# Altium schematic sheet [08] - ISOLATE SIGNAL.SchDoc("[08] - ISOLATE SIGNAL")
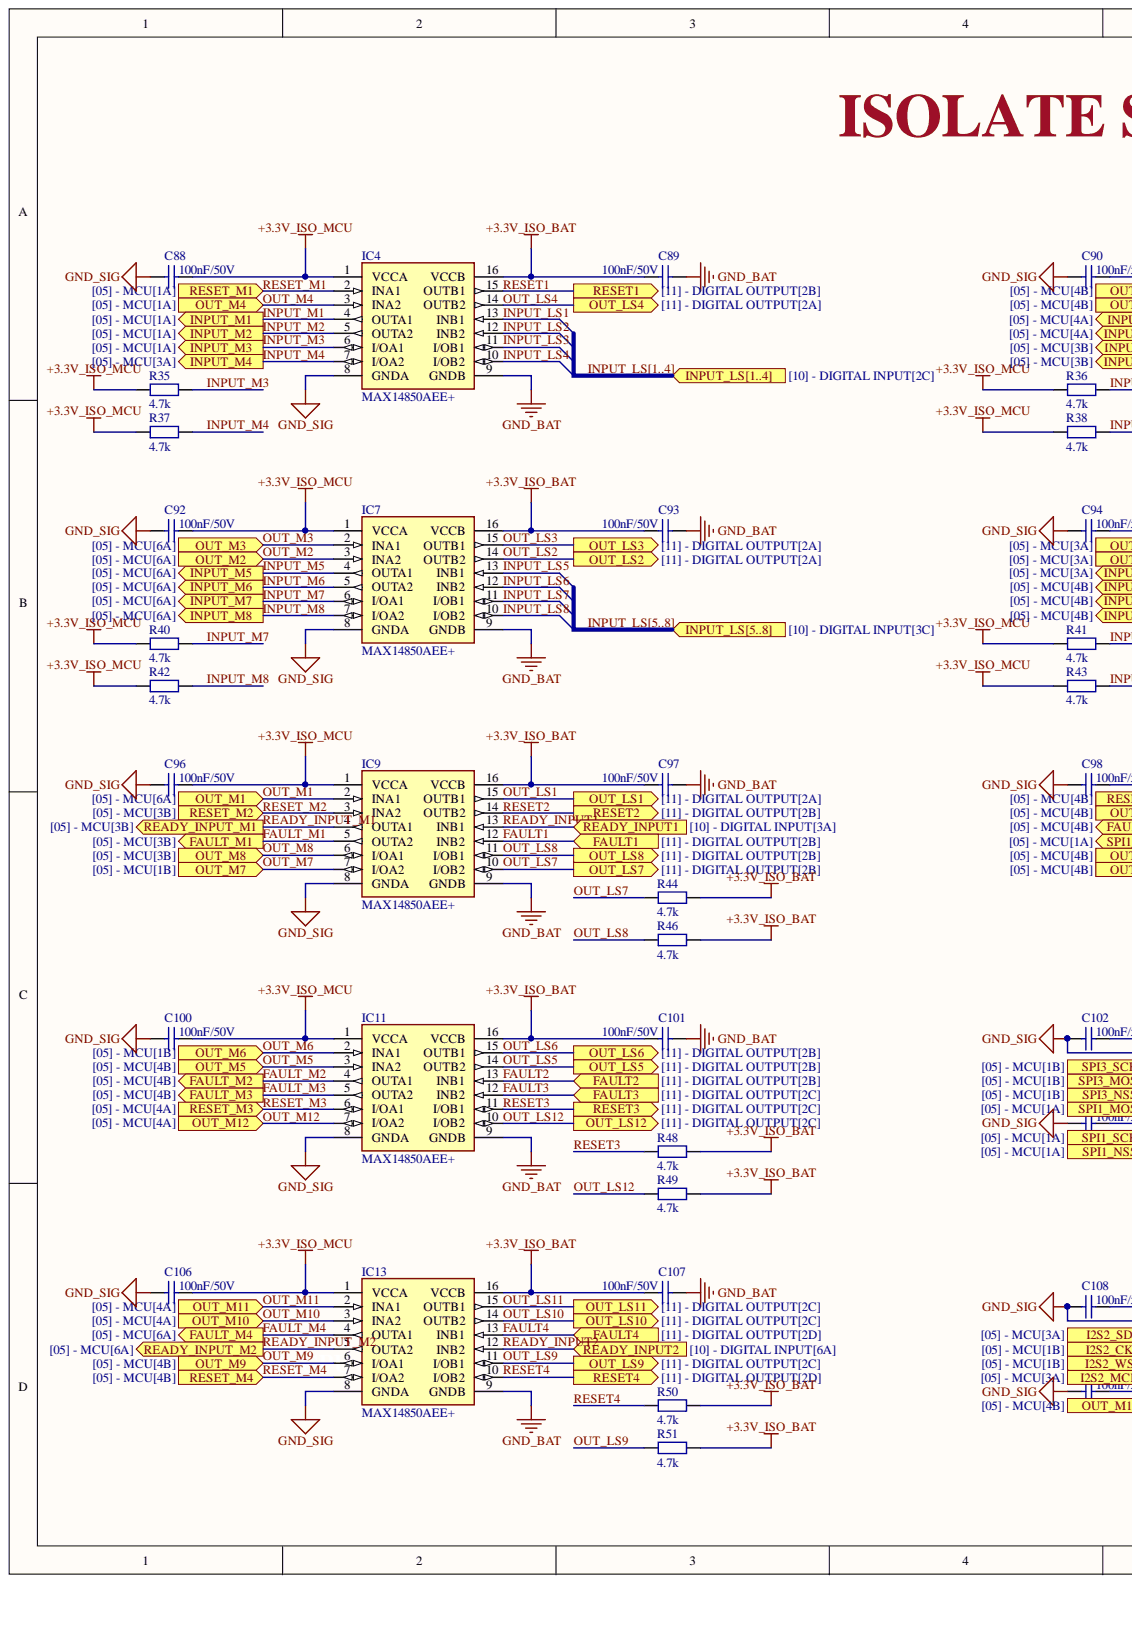
[diagram p0: [08] - ISOLATE SIGNAL.SchDoc, left]
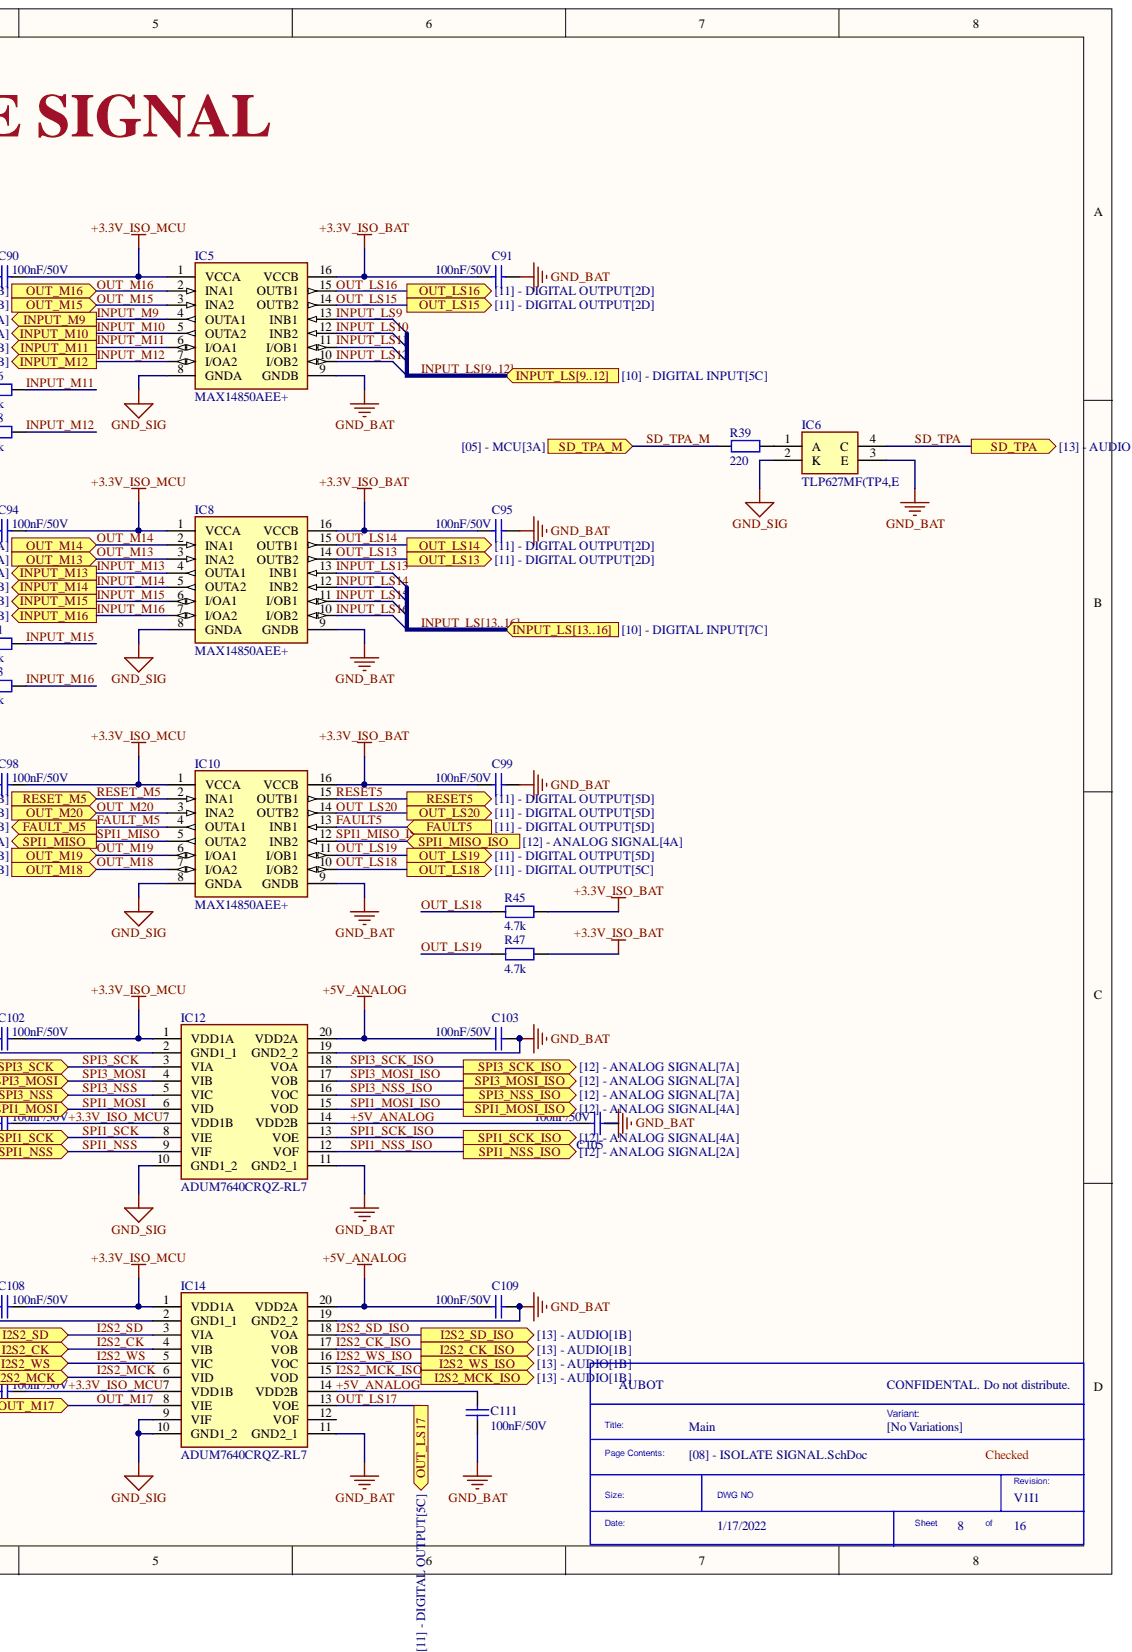
[diagram p1: [08] - ISOLATE SIGNAL.SchDoc, right]

## Parts
C88  100nF/50V  [CAP 0805]
C89  100nF/50V  [CAP 0805]
C90  100nF/50V  [CAP 0805]
C91  100nF/50V  [CAP 0805]
C92  100nF/50V  [CAP 0805]
C93  100nF/50V  [CAP 0805]
C94  100nF/50V  [CAP 0805]
C95  100nF/50V  [CAP 0805]
C96  100nF/50V  [CAP 0805]
C97  100nF/50V  [CAP 0805]
C98  100nF/50V  [CAP 0805]
C99  100nF/50V  [CAP 0805]
C100  100nF/50V  [CAP 0805]
C101  100nF/50V  [CAP 0805]
C102  100nF/50V  [CAP 0805]
C103  100nF/50V  [CAP 0805]
C104  100nF/50V  [CAP 0805]
C105  100nF/50V  [CAP 0805]
C106  100nF/50V  [CAP 0805]
C107  100nF/50V  [CAP 0805]
C108  100nF/50V  [CAP 0805]
C109  100nF/50V  [CAP 0805]
C110  100nF/50V  [CAP 0805]
C111  100nF/50V  [CAP 0805]
IC4  MAX14850AEE+  [QSOP16]
IC5  MAX14850AEE+  [QSOP16]
IC6  TLP627MF(TP4,E  [SOP4-OPTO]
IC7  MAX14850AEE+  [QSOP16]
IC8  MAX14850AEE+  [QSOP16]
IC9  MAX14850AEE+  [QSOP16]
IC10  MAX14850AEE+  [QSOP16]
IC11  MAX14850AEE+  [QSOP16]
IC12  ADUM7640CRQZ-RL7  [20-Lead QSOP]
IC13  MAX14850AEE+  [QSOP16]
IC14  ADUM7640CRQZ-RL7  [20-Lead QSOP]
R35  4.7k  [RES 0805]
R36  4.7k  [RES 0805]
R37  4.7k  [RES 0805]
R38  4.7k  [RES 0805]
R39  220  [RES 0805]
R40  4.7k  [RES 0805]
R41  4.7k  [RES 0805]
R42  4.7k  [RES 0805]
R43  4.7k  [RES 0805]
R44  4.7k  [RES 0805]
R45  4.7k  [RES 0805]
R46  4.7k  [RES 0805]
R47  4.7k  [RES 0805]
R48  4.7k  [RES 0805]
R49  4.7k  [RES 0805]
R50  4.7k  [RES 0805]
R51  4.7k  [RES 0805]

## Ports
FAULT1, FAULT2, FAULT3, FAULT4, FAULT5, FAULT_M1, FAULT_M2, FAULT_M3, FAULT_M4, FAULT_M5, I2S2_CK, I2S2_CK_ISO, I2S2_MCK, I2S2_MCK_ISO, I2S2_SD, I2S2_SD_ISO, I2S2_WS, I2S2_WS_ISO, INPUT_LS1, INPUT_LS2, INPUT_LS3, INPUT_LS4, INPUT_LS5, INPUT_LS6, INPUT_LS7, INPUT_LS8, INPUT_LS9, INPUT_LS10, INPUT_LS11, INPUT_LS12, INPUT_LS13, INPUT_LS14, INPUT_LS15, INPUT_LS16, INPUT_LS[1..4], INPUT_LS[5..8], INPUT_LS[9..12], INPUT_LS[13..16], INPUT_M1, INPUT_M2, INPUT_M3, INPUT_M4, INPUT_M5, INPUT_M6, INPUT_M7, INPUT_M8, INPUT_M9, INPUT_M10, INPUT_M11, INPUT_M12, INPUT_M13, INPUT_M14, INPUT_M15, INPUT_M16, OUT_LS1, OUT_LS2, OUT_LS3, OUT_LS4, OUT_LS5, OUT_LS6, OUT_LS7, OUT_LS8, OUT_LS9, OUT_LS10, OUT_LS11, OUT_LS12, OUT_LS13, OUT_LS14, OUT_LS15, OUT_LS16, OUT_LS17, OUT_LS18, OUT_LS19, OUT_LS20, OUT_M1, OUT_M2, OUT_M3, OUT_M4, OUT_M5, OUT_M6, OUT_M7, OUT_M8, OUT_M9, OUT_M10, OUT_M11, OUT_M12, OUT_M13, OUT_M14, OUT_M15, OUT_M16, OUT_M17, OUT_M18, OUT_M19, OUT_M20, READY_INPUT1, READY_INPUT2, READY_INPUT_M1, READY_INPUT_M2, RESET1, RESET2, RESET3, RESET4, RESET5, RESET_M1, RESET_M2, RESET_M3, RESET_M4, RESET_M5, SD_TPA, SD_TPA_M, SPI1_MISO, SPI1_MISO_ISO, SPI1_MOSI, SPI1_MOSI_ISO, SPI1_NSS, SPI1_NSS_ISO, SPI1_SCK, SPI1_SCK_ISO, SPI3_MOSI, SPI3_MOSI_ISO, SPI3_NSS, SPI3_NSS_ISO, SPI3_SCK, SPI3_SCK_ISO

## Nets
+3.3V_ISO_BAT: C89.1 C91.1 C93.1 C95.1 C97.1 C99.1 C101.1 C107.1 IC4.16(VCCB) IC5.16(VCCB) IC7.16(VCCB) IC8.16(VCCB) IC9.16(VCCB) IC10.16(VCCB) IC11.16(VCCB) IC13.16(VCCB) R44.2 R45.2 R46.2 R47.2 R48.2 R49.2 R50.2 R51.2
GND_BAT: C89.2 C91.2 C93.2 C95.2 C97.2 C99.2 C101.2 C103.2 C105.2 C107.2 C109.2 C111.1 IC4.9(GNDB) IC5.9(GNDB) IC6.3(E) IC7.9(GNDB) IC8.9(GNDB) IC9.9(GNDB) IC10.9(GNDB) IC11.9(GNDB) IC12.11(GND2_1) IC12.19(GND2_2) IC13.9(GNDB) IC14.11(GND2_1) IC14.19(GND2_2)
GND_SIG: C88.1 C90.1 C92.1 C94.1 C96.1 C98.1 C100.1 C102.1 C104.1 C106.1 C108.1 C110.1 IC4.8(GNDA) IC5.8(GNDA) IC6.2(K) IC7.8(GNDA) IC8.8(GNDA) IC9.8(GNDA) IC10.8(GNDA) IC11.8(GNDA) IC12.2(GND1_1) IC12.10(GND1_2) IC13.8(GNDA) IC14.2(GND1_1) IC14.9(VIF) IC14.10(GND1_2)
+3.3V_ISO_MCU: C88.2 C90.2 C92.2 C94.2 C96.2 C98.2 C100.2 C102.2 C104.2 C106.2 C108.2 C110.2 IC4.1(VCCA) IC5.1(VCCA) IC7.1(VCCA) IC8.1(VCCA) IC9.1(VCCA) IC10.1(VCCA) IC11.1(VCCA) IC12.1(VDD1A) IC12.7(VDD1B) IC13.1(VCCA) IC14.1(VDD1A) IC14.7(VDD1B) R35.1 R36.1 R37.1 R38.1 R40.1 R41.1 R42.1 R43.1
+5V_ANALOG: C103.1 C105.1 C109.1 C111.2 IC12.14(VDD2B) IC12.20(VDD2A) IC14.14(VDD2B) IC14.20(VDD2A)
FAULT1: IC9.12(INB2) ; port FAULT1
FAULT2: IC11.13(INB1) ; port FAULT2
FAULT3: IC11.12(INB2) ; port FAULT3
FAULT4: IC13.13(INB1) ; port FAULT4
FAULT5: IC10.13(INB1) ; port FAULT5
FAULT_M1: IC9.5(OUTA2) ; port FAULT_M1
FAULT_M2: IC11.4(OUTA1) ; port FAULT_M2
FAULT_M3: IC11.5(OUTA2) ; port FAULT_M3
FAULT_M4: IC13.4(OUTA1) ; port FAULT_M4
FAULT_M5: IC10.4(OUTA1) ; port FAULT_M5
I2S2_CK: IC14.4(VIB) ; port I2S2_CK
I2S2_CK_ISO: IC14.17(VOB) ; port I2S2_CK_ISO
I2S2_MCK: IC14.6(VID) ; port I2S2_MCK
I2S2_MCK_ISO: IC14.15(VOD) ; port I2S2_MCK_ISO
I2S2_SD: IC14.3(VIA) ; port I2S2_SD
I2S2_SD_ISO: IC14.18(VOA) ; port I2S2_SD_ISO
I2S2_WS: IC14.5(VIC) ; port I2S2_WS
I2S2_WS_ISO: IC14.16(VOC) ; port I2S2_WS_ISO
INPUT_LS1: IC4.13(INB1) ; port INPUT_LS[1..4]  [also labelled INPUT_LS]
INPUT_LS2: IC4.12(INB2) ; port INPUT_LS[1..4]  [also labelled INPUT_LS]
INPUT_LS3: IC4.11(I/OB1) ; port INPUT_LS[1..4]  [also labelled INPUT_LS]
INPUT_LS4: IC4.10(I/OB2) ; port INPUT_LS[1..4]  [also labelled INPUT_LS]
INPUT_LS5: IC7.13(INB1) ; port INPUT_LS[5..8]  [also labelled INPUT_LS]
INPUT_LS6: IC7.12(INB2) ; port INPUT_LS[5..8]  [also labelled INPUT_LS]
INPUT_LS7: IC7.11(I/OB1) ; port INPUT_LS[5..8]  [also labelled INPUT_LS]
INPUT_LS8: IC7.10(I/OB2) ; port INPUT_LS[5..8]  [also labelled INPUT_LS]
INPUT_LS9: IC5.13(INB1) ; port INPUT_LS[9..12]  [also labelled INPUT_LS]
INPUT_LS10: IC5.12(INB2) ; port INPUT_LS[9..12]  [also labelled INPUT_LS]
INPUT_LS11: IC5.11(I/OB1) ; port INPUT_LS[9..12]  [also labelled INPUT_LS]
INPUT_LS12: IC5.10(I/OB2) ; port INPUT_LS[9..12]  [also labelled INPUT_LS]
INPUT_LS13: IC8.13(INB1) ; port INPUT_LS[13..16]  [also labelled INPUT_LS]
INPUT_LS14: IC8.12(INB2) ; port INPUT_LS[13..16]  [also labelled INPUT_LS]
INPUT_LS15: IC8.11(I/OB1) ; port INPUT_LS[13..16]  [also labelled INPUT_LS]
INPUT_LS16: IC8.10(I/OB2) ; port INPUT_LS[13..16]  [also labelled INPUT_LS]
INPUT_M1: IC4.4(OUTA1) ; port INPUT_M1
INPUT_M2: IC4.5(OUTA2) ; port INPUT_M2
INPUT_M3: IC4.6(I/OA1) R35.2 ; port INPUT_M3
INPUT_M4: IC4.7(I/OA2) R37.2 ; port INPUT_M4
INPUT_M5: IC7.4(OUTA1) ; port INPUT_M5
INPUT_M6: IC7.5(OUTA2) ; port INPUT_M6
INPUT_M7: IC7.6(I/OA1) R40.2 ; port INPUT_M7
INPUT_M8: IC7.7(I/OA2) R42.2 ; port INPUT_M8
INPUT_M9: IC5.4(OUTA1) ; port INPUT_M9
INPUT_M10: IC5.5(OUTA2) ; port INPUT_M10
INPUT_M11: IC5.6(I/OA1) R36.2 ; port INPUT_M11
INPUT_M12: IC5.7(I/OA2) R38.2 ; port INPUT_M12
INPUT_M13: IC8.4(OUTA1) ; port INPUT_M13
INPUT_M14: IC8.5(OUTA2) ; port INPUT_M14
INPUT_M15: IC8.6(I/OA1) R41.2 ; port INPUT_M15
INPUT_M16: IC8.7(I/OA2) R43.2 ; port INPUT_M16
OUT_LS1: IC9.15(OUTB1) ; port OUT_LS1
OUT_LS2: IC7.14(OUTB2) ; port OUT_LS2
OUT_LS3: IC7.15(OUTB1) ; port OUT_LS3
OUT_LS4: IC4.14(OUTB2) ; port OUT_LS4
OUT_LS5: IC11.14(OUTB2) ; port OUT_LS5
OUT_LS6: IC11.15(OUTB1) ; port OUT_LS6
OUT_LS7: IC9.10(I/OB2) R44.1 ; port OUT_LS7
OUT_LS8: IC9.11(I/OB1) R46.1 ; port OUT_LS8
OUT_LS9: IC13.11(I/OB1) R51.1 ; port OUT_LS9
OUT_LS10: IC13.14(OUTB2) ; port OUT_LS10
OUT_LS11: IC13.15(OUTB1) ; port OUT_LS11
OUT_LS12: IC11.10(I/OB2) R49.1 ; port OUT_LS12
OUT_LS13: IC8.14(OUTB2) ; port OUT_LS13
OUT_LS14: IC8.15(OUTB1) ; port OUT_LS14
OUT_LS15: IC5.14(OUTB2) ; port OUT_LS15
OUT_LS16: IC5.15(OUTB1) ; port OUT_LS16
OUT_LS17: IC14.13(VOE) ; port OUT_LS17
OUT_LS18: IC10.10(I/OB2) R45.1 ; port OUT_LS18
OUT_LS19: IC10.11(I/OB1) R47.1 ; port OUT_LS19
OUT_LS20: IC10.14(OUTB2) ; port OUT_LS20
OUT_M1: IC9.2(INA1) ; port OUT_M1
OUT_M2: IC7.3(INA2) ; port OUT_M2
OUT_M3: IC7.2(INA1) ; port OUT_M3
OUT_M4: IC4.3(INA2) ; port OUT_M4
OUT_M5: IC11.3(INA2) ; port OUT_M5
OUT_M6: IC11.2(INA1) ; port OUT_M6
OUT_M7: IC9.7(I/OA2) ; port OUT_M7
OUT_M8: IC9.6(I/OA1) ; port OUT_M8
OUT_M9: IC13.6(&) ; port OUT_M9
OUT_M10: IC13.3(INA2) ; port OUT_M10
OUT_M11: IC13.2(INA1) ; port OUT_M11
OUT_M12: IC11.7(I/OA2) ; port OUT_M12
OUT_M13: IC8.3(INA2) ; port OUT_M13
OUT_M14: IC8.2(INA1) ; port OUT_M14
OUT_M15: IC5.3(INA2) ; port OUT_M15
OUT_M16: IC5.2(INA1) ; port OUT_M16
OUT_M17: IC14.8(VIE) ; port OUT_M17
OUT_M18: IC10.7(I/OA2) ; port OUT_M18
OUT_M19: IC10.6(I/OA1) ; port OUT_M19
OUT_M20: IC10.3(INA2) ; port OUT_M20
READY_INPUT1: IC9.13(INB1) ; port READY_INPUT1
READY_INPUT2: IC13.12(INB2) ; port READY_INPUT2
READY_INPUT_M1: IC9.4(OUTA1) ; port READY_INPUT_M1
READY_INPUT_M2: IC13.5(OUTA2) ; port READY_INPUT_M2
RESET1: IC4.15(OUTB1) ; port RESET1
RESET2: IC9.14(OUTB2) ; port RESET2
RESET3: IC11.11(I/OB1) R48.1 ; port RESET3
RESET4: IC13.10(I/OB2) R50.1 ; port RESET4
RESET5: IC10.15(OUTB1) ; port RESET5
RESET_M1: IC4.2(INA1) ; port RESET_M1
RESET_M2: IC9.3(INA2) ; port RESET_M2
RESET_M3: IC11.6(I/OA1) ; port RESET_M3
RESET_M4: IC13.7(I/OA2) ; port RESET_M4
RESET_M5: IC10.2(INA1) ; port RESET_M5
SD_TPA: IC6.4(C) ; port SD_TPA
SD_TPA_M: R39.2 ; port SD_TPA_M
SPI1_MISO: IC10.5(OUTA2) ; port SPI1_MISO
SPI1_MISO_ISO: IC10.12(INB2) ; port SPI1_MISO_ISO
SPI1_MOSI: IC12.6(VID) ; port SPI1_MOSI
SPI1_MOSI_ISO: IC12.15(VOD) ; port SPI1_MOSI_ISO
SPI1_NSS: IC12.9(VIF) ; port SPI1_NSS
SPI1_NSS_ISO: IC12.12(VOF) ; port SPI1_NSS_ISO
SPI1_SCK: IC12.8(VIE) ; port SPI1_SCK
SPI1_SCK_ISO: IC12.13(VOE) ; port SPI1_SCK_ISO
SPI3_MOSI: IC12.4(VIB) ; port SPI3_MOSI
SPI3_MOSI_ISO: IC12.17(VOB) ; port SPI3_MOSI_ISO
SPI3_NSS: IC12.5(VIC) ; port SPI3_NSS
SPI3_NSS_ISO: IC12.16(VOC) ; port SPI3_NSS_ISO
SPI3_SCK: IC12.3(VIA) ; port SPI3_SCK
SPI3_SCK_ISO: IC12.18(VOA) ; port SPI3_SCK_ISO
NetIC6_1: IC6.1(A) R39.1

Not connected: IC14 12(VOF)

## Library data of the parts
C88-C111 = Cap 0805 100nF/50V: Ceramic capacitor SMD-0805 100nF /50V X7R# K +-10%, Samsung, RoHS; Manufacturer Part Number: CL21B104KBCNNNC; Voltage Rating (DC): 50V; Tolerance: 10%; Dielectric: X7R; Width: 0.049inch; Voltage Rating: 50V; Voltage: 50V; Thickness: 0.037inch; Supplier Part Number 1: C1711; Supplier 1: LCSC; Stock 1: 447650; Series: CL; RoHS Compliant: Yes; RoHS: Yes; Radiation Hardening: No; Pricing 1: 50 = 0.04090, 500 = 0.03130, 1500 = 0.02890, 5000 = 0.02580, 25000 = 0.02430, 50000 = 0.02410, 50 = 0.03950, 500 = 0.03020, 1500 = 0.02790, 5000 = 0.02490, 25000 = 0.02350, 50000 = 0.02330, 50 = 0.20680, 500 = 0.15820, 1500 = 0.14600, 5000 = 0.13020, 25000 = 0.12290, 50000 = 0.12170, 50 = 0.19640, 500 = 0.15020, 1500 = 0.13860, 5000 = 0.12360, 25000 = 0.11670, 50000 = 0.11550, 50 = 0.02640, 500 = 0.02020, 1500 = 0.01860, 5000 = 0.01660, 25000 = 0.01570, 50000 = 0.01550, 50 = 0.02300, 500 = 0.01760, 1500 = 0.01620, 5000 = 0.01440, 25000 = 0.01360, 50000 = 0.01350, 50 = 0.24740, 500 = 0.18920, 1500 = 0.17470, 5000 = 0.15570, 25000 = 0.14700, 50000 = 0.14560, 50 = 3.45220, 500 = 2.64000, 1500 = 2.43700, 5000 = 2.17300, 25000 = 2.05110, 50000 = 2.03080, 50 = 0.13070, 500 = 0.09990, 1500 = 0.09220, 5000 = 0.08230, 25000 = 0.07760, 50000 = 0.07690, 50 = 2.39050, 500 = 1.82810, 1500 = 1.68750, 5000 = 1.50470, 25000 = 1.42030, 50000 = 1.40620, 50 = 0.26740, 500 = 0.20450, 1500 = 0.18870, 5000 = 0.16830, 25000 = 0.15890, 50000 = 0.15730, 50 = 0.04230, 500 = 0.03230, 1500 = 0.02990, 5000 = 0.02660, 25000 = 0.02510, 50000 = 0.02490, 50 = 0.03188, 500 = 0.02438, 1500 = 0.02251, 5000 = 0.02007, 25000 = 0.01894, 50000 = 0.01875 (AUD); Packaging: Tape and Reel; Mount: Surface Mount; Min Operating Temperature: -55°C; Max Operating Temperature: 125°C; Material: Ceramic; Manufacturer: Samsung; Length: 2mm; Lead Free: Lead Free; Height: 0.85mm; Depth: 1.25mm; Contact Plating: Tin; Construction: Flat; ComponentLink2URL: https://datasheet.ciiva.com/29199/454152-da-01-en-vs-kondensator-0805-29199814.pdf?src-supplier=Conrad; ComponentLink2Description: https://datasheet.ciiva.com/29199/454152-da-01-en-vs-kondensator-0805-29199814.pdf?src-supplier=Conrad; ComponentLink1URL: https://datasheet.ciiva.com/25710/cl21b104kbcnnnc-25710182.pdf?src-supplier=Digi-Key; ComponentLink1Description: https://datasheet.ciiva.com/25710/cl21b104kbcnnnc-25710182.pdf?src-supplier=Digi-Key; Component Kind: Standard; Case Code (Metric): 2012; Case Code (Imperial): 0805; Case/Package: 0805; Capacitance: 100nF; Library Name: Hieu_Lib.IntLib
IC4 IC5 IC7-IC11 IC13 = MAX14850AEE+: MAX14850 Series 6000 Vrm 771.5 mW Six-Channel Digital Isolator - QSOP-16; Manufacturer: Maxim; Number of Channels: 6; Isolated Power: No; Data Rate: 50Mbps; Channel Type: Bidirectional; Type: General Purpose; Supplier Part Number 1: 700-MAX14850AEE+; Supplier 1: Mouser; Stock 1: 2204; RoHS Compliant: Yes; RoHS: Yes; Pricing 1: 1 = 2.73000, 10 = 2.44000, 50 = 2.30000, 100 = 2.10000, 1000 = 2.05000, 10000 = 2.05000 (USD); Packaging: Rail/Tube; Min Operating Temperature: -40°C; Max Operating Temperature: 125°C; Manufacturer Part Number: MAX14850AEE+; ComponentLink3URL: http://datasheets.maximintegrated.com/en/ds/MAX14850.pdf?src-supplier=Digi-Key; ComponentLink3Description: http://datasheets.maximintegrated.com/en/ds/MAX14850.pdf?src-supplier=Digi-Key; ComponentLink2URL: http://datasheets.maximintegrated.com/en/ds/MAX14850.pdf?src-supplier=Avnet; ComponentLink2Description: http://datasheets.maximintegrated.com/en/ds/MAX14850.pdf?src-supplier=Avnet; ComponentLink1URL: http://www.farnell.com/datasheets/2001849.pdf?src-supplier=Farnell; ComponentLink1Description: http://www.farnell.com/datasheets/2001849.pdf?src-supplier=Farnell; Component Kind: Standard; Case/Package: SSOP; Library Name: Hieu_Lib.SchLib
IC6 = TLP627MF(TP4,E: Optocoupler 1-Channel Darlington 50mA DC-Out 4-Pin DIP T/R; Manufacturer: Toshiba; Supplier Part Number 1: 757-TLP627MFTP4E; Supplier 1: Mouser; Stock 1: 2383; RoHS: Yes; Pricing 1: 1 = 0.96000, 10 = 0.60400, 50 = 0.60400, 100 = 0.54400, 250 = 0.48400, 500 = 0.41100, 1000 = 0.33800, 2000 = 0.29200, 5000 = 0.28600, 10000 = 0.27400 (USD); Manufacturer Part Number: TLP627MF(TP4,E; Component Kind: Standard; Library Name: Hieu_Lib.IntLib
IC12 IC14 = ADUM7640CRQZ-RL7: 1 KV SIX-CHANNEL DIGITAL ISOLATORS; Manufacturer: Analog Devices; Turn-On Delay Time: 50ns; Supply Current: 1.16mA; Pulse Width Distortion (PWD): 6ns; Number of Channels: 6; Max Supply Voltage: 5.5V; Isolation Voltage: 420V; Isolated Power: No; Data Rate: 25Mbps; Channel Type: Unidirectional; Type: General Purpose; Supplier Part Number 1: 584-ADUM7640CRQZ-R7; Supplier 1: Mouser; Stock 1: 1421; Series: iCoupler®; RoHS Compliant: Yes; RoHS: Yes; Propagation Delay: 50ns; Pricing 1: 1 = 8.66000, 10 = 7.82000, 25 = 7.46000, 50 = 7.46000, 100 = 6.48000, 250 = 6.19000, 500 = 5.64000, 1000 = 5.14000, 10000 = 5.14000 (USD); Packaging: Tape and Reel; Package Quantity: 1000; Number of Pins: 20; Nominal Supply Current: 1.16mA; Min Operating Temperature: -40°C; Max Operating Temperature: 105°C; Manufacturer Part Number: ADUM7640CRQZ-RL7; Lead Free: Contains Lead; ComponentLink4URL: http://components.arrow.com/part/dataview/56727037S370968N2771?src-supplier=Arrow+Electronics; ComponentLink4Description: http://components.arrow.com/part/dataview/56727037S370968N2771?src-supplier=Arrow+Electronics; ComponentLink3URL: http://www.analog.com/media/en/technical-documentation/data-sheets/ADuM7640_7641_7642_7643.pdf?src-supplier=Digi-Key; ComponentLink3Description: http://www.analog.com/media/en/technical-documentation/data-sheets/ADuM7640_7641_7642_7643.pdf?src-supplier=Digi-Key; ComponentLink2URL: http://www.mouser.com/ds/2/609/ADuM7640_7641_7642_7643-879038.pdf?src-supplier=Mouser; ComponentLink2Description: http://www.mouser.com/ds/2/609/ADuM7640_7641_7642_7643-879038.pdf?src-supplier=Mouser; ComponentLink1URL: http://components.arrow.com/part/dataview/1762_06358735?src-supplier=Arrow+Europe; ComponentLink1Description: http://components.arrow.com/part/dataview/1762_06358735?src-supplier=Arrow+Europe; Component Kind: Standard; Case/Package: QSOP; Library Name: Hieu_Lib.IntLib
R35-R38 R40-R51 = Res 0805 4.7k Ohm 1%: General Purpose Chip Resistor Thick Film 0805 4.7kOhm 1% Paper T/R; Manufacturer Part Number: RC0805FR-074K7L; Manufacturer: Yageo; Voltage Rating (DC): 150V; Temperature Coefficient: 100ppm/°C; Resistance: 4.7kR; Power Rating: 125mW; Width: 0.049inch; Voltage Rating: 150V; Tolerance: 1%; Termination: SMD/SMT; Technology: THICK FILM; Supplier Part Number 1: 311-4.70KCRCT-ND; Supplier 1: Digi-Key; Stock 1: 159494; Size Code: 0805; Series: RC; RoHS Compliant: Yes; RoHS: Yes; Resistor Type: Thick Film; Resistance Tolerance: 1%; REACH SVHC: No SVHC; Pricing 1: 1 = 0.10000, 10 = 0.04200, 100 = 0.01690, 1000 = 0.00759, 2500 = 0.00659 (USD); Packaging: Tape and Reel; Package Quantity: 5000; Number of Terminations: 2; Number of Pins: 2; Min Operating Temperature: -55°C; Military Standard: Not; Max Power Dissipation: 125mW; Max Operating Temperature: 155°C; Length: 0.079inch; Lead Free: Lead Free; Height: 0.024inch; Features: Moisture Resistant; Depth: 1.25mm; Construction: Rectangular; ComponentLink6URL: https://datasheet.ciiva.com/46447/444164-46447583.pdf?src-supplier=Element14; ComponentLink6Description: https://datasheet.ciiva.com/46447/444164-46447583.pdf?src-supplier=Element14; ComponentLink5URL: https://datasheet.ciiva.com/6547/pyu-r-int-thick-7-6547263.pdf?src-supplier=Digi-Key; ComponentLink5Description: https://datasheet.ciiva.com/6547/pyu-r-int-thick-7-6547263.pdf?src-supplier=Digi-Key; ComponentLink4URL: https://datasheet.ciiva.com/7941/444164-7941806.pdf?src-supplier=Element14; ComponentLink4Description: https://datasheet.ciiva.com/7941/444164-7941806.pdf?src-supplier=Element14; ComponentLink3URL: https://datasheet.ciiva.com/7941/1686128-7941805.pdf?src-supplier=Element14; ComponentLink3Description: https://datasheet.ciiva.com/7941/1686128-7941805.pdf?src-supplier=Element14; ComponentLink2URL: https://datasheet.ciiva.com/7941/444164-7941804.pdf?src-supplier=Element14; ComponentLink2Description: https://datasheet.ciiva.com/7941/444164-7941804.pdf?src-supplier=Element14; ComponentLink1URL: https://datasheet.ciiva.com/11808/0900766b8106beab-11808155.pdf?src-supplier=RSComponents; ComponentLink1Description: https://datasheet.ciiva.com/11808/0900766b8106beab-11808155.pdf?src-supplier=RSComponents; Component Kind: Standard; Case Code (Metric): 2012; Case Code (Imperial): 0805; Case/Package: 0805; Library Name: Hieu_Lib.SchLib
R39 = Res 0805 220 Ohm 1%: Res SMD 0805 220R 1% 0.125W T.C.100PPM; Manufacturer Part Number: RC0805FR-07220RL; Manufacturer: Yageo; Voltage Rating: 150V; Temperature Coefficient: 100ppm/°C; Power Rating: 125mW; Width: 0.049inch; Tolerance: 1%; Termination: SMD/SMT; Technology: THICK FILM; Supplier Part Number 1: 311-220CRCT-ND; Supplier 1: Digi-Key; Stock 1: 316498; Size Code: 0805; Series: RC; RoHS Compliant: Yes; RoHS: Yes; Resistor Type: Thick Film; Resistance Tolerance: 1%; Resistance: 220R; REACH SVHC: Unknown; Pricing 1: 1 = 0.10000, 10 = 0.04200, 100 = 0.01690, 1000 = 0.00759, 2500 = 0.00659 (USD); Packaging: Tape and Reel; Package Quantity: 5000; Number of Terminations: 2; Min Operating Temperature: -55°C; Military Standard: Not; Max Power Dissipation: 125mW; Max Operating Temperature: 155°C; Length: 2mm; Lead Free: Lead Free; Height: 0.5mm; Features: Moisture Resistant; Depth: 1.25mm; Construction: Rectangular; ComponentLink6URL: https://datasheet.ciiva.com/7941/444164-7941741.pdf?src-supplier=Element14; ComponentLink6Description: https://datasheet.ciiva.com/7941/444164-7941741.pdf?src-supplier=Element14; ComponentLink5URL: https://datasheet.ciiva.com/7941/444164-7941740.pdf?src-supplier=Element14; ComponentLink5Description: https://datasheet.ciiva.com/7941/444164-7941740.pdf?src-supplier=Element14; ComponentLink4URL: https://datasheet.ciiva.com/2457/pyu-r-int-thick-7-2457385.pdf?src-supplier=Digi-Key; ComponentLink4Description: https://datasheet.ciiva.com/2457/pyu-r-int-thick-7-2457385.pdf?src-supplier=Digi-Key; ComponentLink3URL: https://datasheet.ciiva.com/2457/pyu-r-int-thick-7-2457384.pdf?src-supplier=Digi-Key; ComponentLink3Description: https://datasheet.ciiva.com/2457/pyu-r-int-thick-7-2457384.pdf?src-supplier=Digi-Key; ComponentLink2URL: https://datasheet.ciiva.com/2457/pyu-r-int-thick-7-2457383.pdf?src-supplier=Digi-Key; ComponentLink2Description: https://datasheet.ciiva.com/2457/pyu-r-int-thick-7-2457383.pdf?src-supplier=Digi-Key; ComponentLink1URL: https://datasheet.ciiva.com/11808/0900766b8106beab-11808180.pdf?src-supplier=RSComponents; ComponentLink1Description: https://datasheet.ciiva.com/11808/0900766b8106beab-11808180.pdf?src-supplier=RSComponents; Component Kind: Standard; Case Code (Metric): 2012; Case Code (Imperial): 0805; Case/Package: 0805; Library Name: Hieu_Lib.IntLib
